# Altium schematic sheet 04_ZYNQ_Config.SchDoc(04_ZYNQ_Config)
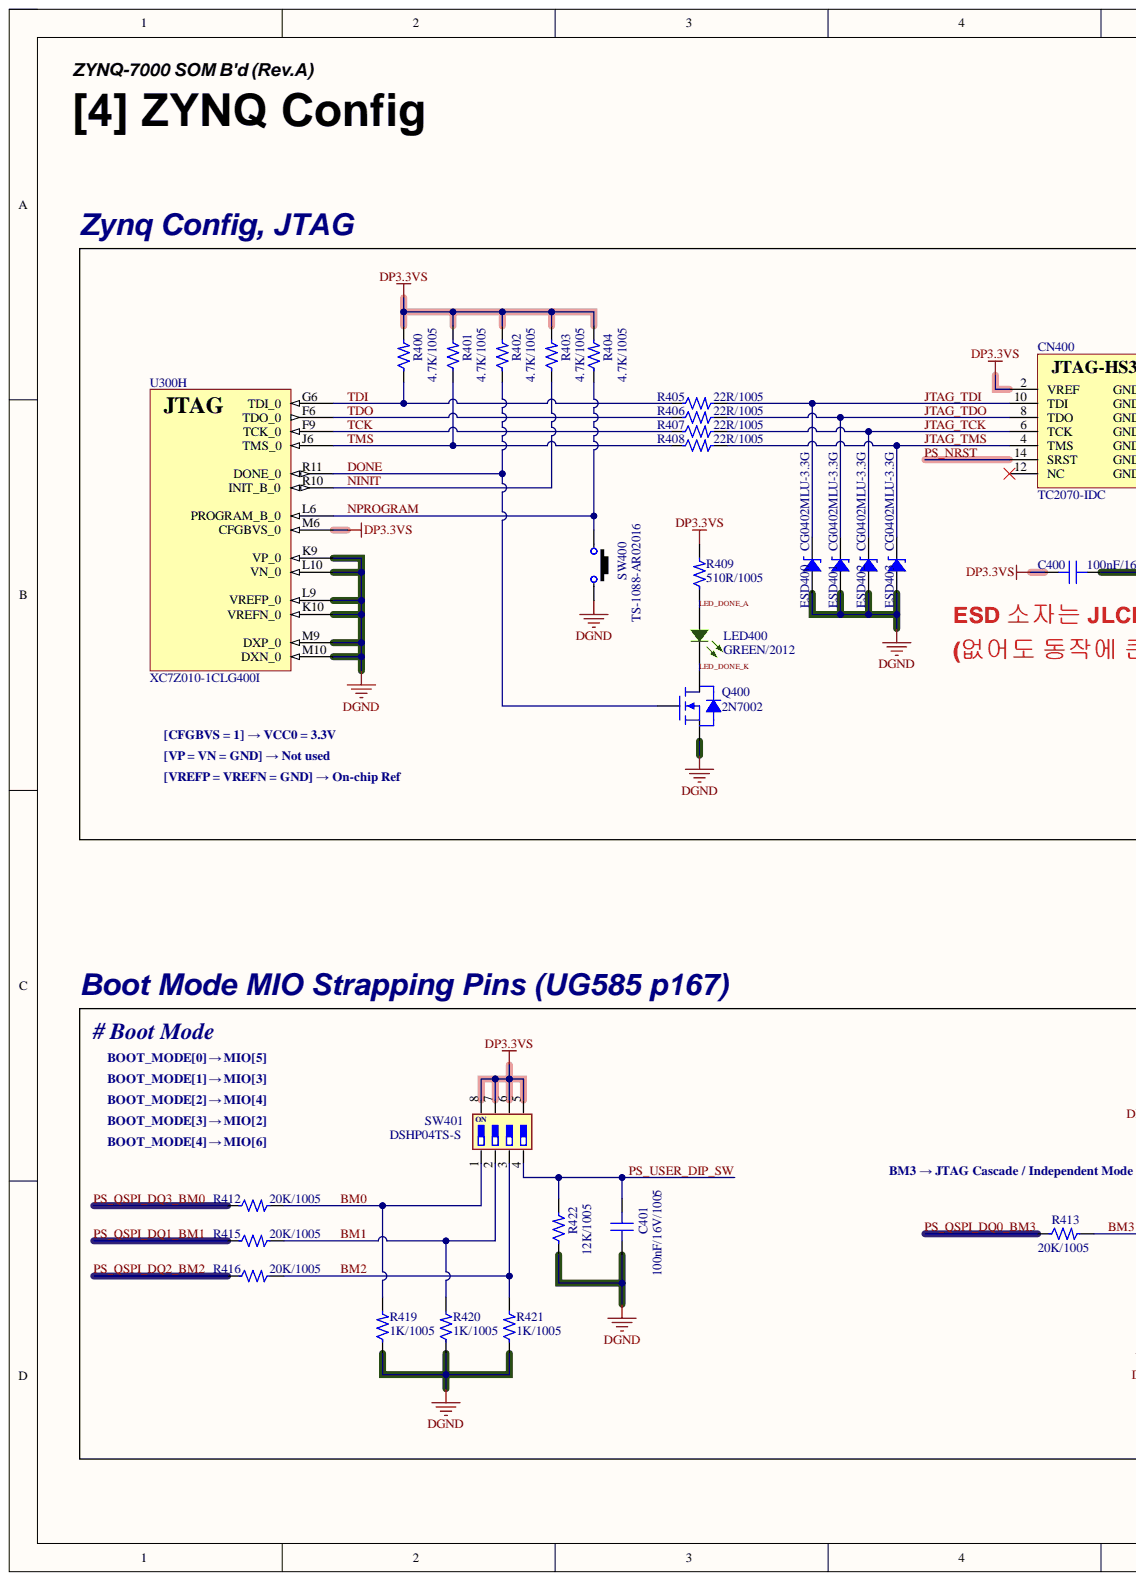
[diagram p0: 04_ZYNQ_Config.SchDoc, left]
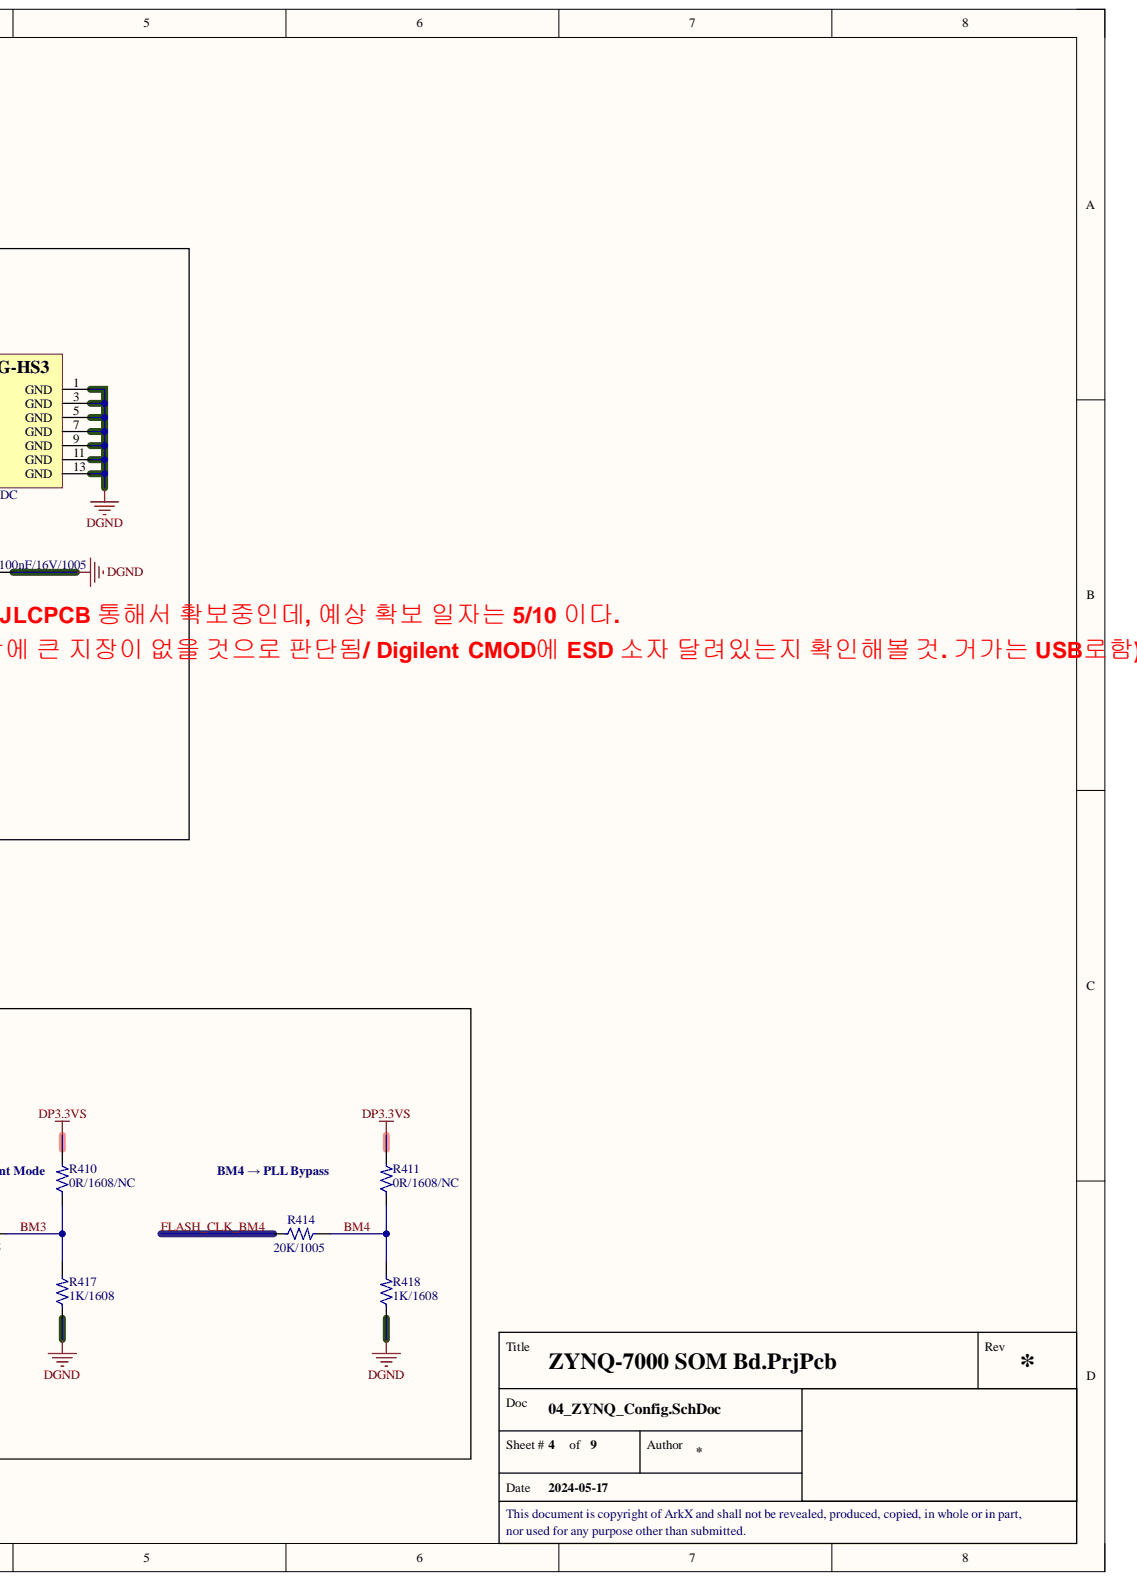
[diagram p1: 04_ZYNQ_Config.SchDoc, right]

## Parts
C400  100nF/16V/1005  [CAP 1005 (0402_INCH)]
C401  100nF/16V/1005  [CAP 1005 (0402_INCH)]
CN400  TC2070-IDC  [CON_TC2070-IDC]
ESD400  CG0402MLU-3.3G  [RES 1005 (0402_inch)]
ESD401  CG0402MLU-3.3G  [RES 1005 (0402_inch)]
ESD402  CG0402MLU-3.3G  [RES 1005 (0402_inch)]
ESD403  CG0402MLU-3.3G  [RES 1005 (0402_inch)]
LED400  GREEN/2012  [LED MHT170RGBCT (GREEN)]
Q400  2N7002  [SOT 23-3 (DIODES INCORPORATED)]
R400  4.7K/1005  [RES 1005 (0402_inch)]
R401  4.7K/1005  [RES 1005 (0402_inch)]
R402  4.7K/1005  [RES 1005 (0402_inch)]
R403  4.7K/1005  [RES 1005 (0402_inch)]
R404  4.7K/1005  [RES 1005 (0402_inch)]
R405  22R/1005  [RES 1005 (0402_inch)]
R406  22R/1005  [RES 1005 (0402_inch)]
R407  22R/1005  [RES 1005 (0402_inch)]
R408  22R/1005  [RES 1005 (0402_inch)]
R409  510R/1005  [RES 1005 (0402_inch)]
R410  0R/1608/NC  [RES 1608 (0603_inch)/NC]
R411  0R/1608/NC  [RES 1608 (0603_inch)/NC]
R412  20K/1005  [RES 1005 (0402_inch)]
R413  20K/1005  [RES 1005 (0402_inch)]
R414  20K/1005  [RES 1005 (0402_inch)]
R415  20K/1005  [RES 1005 (0402_inch)]
R416  20K/1005  [RES 1005 (0402_inch)]
R417  1K/1608  [RES 1608 (0603_inch)]
R418  1K/1608  [RES 1608 (0603_inch)]
R419  1K/1005  [RES 1005 (0402_inch)]
R420  1K/1005  [RES 1005 (0402_inch)]
R421  1K/1005  [RES 1005 (0402_inch)]
R422  12K/1005  [RES 1005 (0402_inch)]
SW400  TS-1088-AR02016  [SW_TS-1088-AR02016]
SW401  DSHP04TS-S  [SW_DSHP04TS-S]
U300  XC7Z010-1CLG400I  [IC_SOC_XC7Z010-1CLG400I]  (part H)

## Nets
DGND: C400.1 C401.1 CN400.1(GND) CN400.3(GND) CN400.5(GND) CN400.7(GND) CN400.9(GND) CN400.11(GND) CN400.13(GND) ESD400.1 ESD401.1 ESD402.1 ESD403.1 Q400.2 R417.1 R418.1 R419.1 R420.1 R421.1 R422.1 SW400.2 U300.K9(VP_0) U300.K10(VREFN_0) U300.L9(VREFP_0) U300.L10(VN_0) U300.M9(DXP_0) U300.M10(DXN_0)
DP3.3VS: C400.2 CN400.2(VREF) R400.2 R401.2 R402.2 R403.2 R404.2 R409.2 R410.2 R411.2 SW401.5 SW401.6 SW401.7 SW401.8(ON) U300.M6(CFGBVS_0)
BM0: R412.2 R419.2 SW401.1
BM1: R415.2 R420.2 SW401.2
BM2: R416.2 R421.2 SW401.3
BM3: R410.1 R413.2 R417.2
BM4: R411.1 R414.2 R418.2
DONE: Q400.1 R402.1 U300.R11(DONE_0)
FLASH_CLK_BM4: R414.1
JTAG_TCK: CN400.6(TCK) ESD402.2 R407.2
JTAG_TDI: CN400.10(TDI) ESD400.2 R405.2
JTAG_TDO: CN400.8(TDO) ESD401.2 R406.2
JTAG_TMS: CN400.4(TMS) ESD403.2 R408.2
LED_DONE_A: LED400.4 R409.1
LED_DONE_K: LED400.2 Q400.3
NINIT: R403.1 U300.R10(INIT_B_0)
NPROGRAM: R404.1 SW400.1 U300.L6(PROGRAM_B_0)
PS_NRST: CN400.14(SRST)
PS_QSPI_DQ0_BM3: R413.1
PS_QSPI_DQ1_BM1: R415.1
PS_QSPI_DQ2_BM2: R416.1
PS_QSPI_DQ3_BM0: R412.1
PS_USER_DIP_SW: C401.2 R422.2 SW401.4
TCK: R407.1 U300.F9(TCK_0)
TDI: R400.1 R405.1 U300.G6(TDI_0)
TDO: R406.1 U300.F6(TDO_0)
TMS: R401.1 R408.1 U300.J6(TMS_0)

Not connected: CN400 12(NC)

## Library data of the parts
C400 C401 = CAP_100nF/16V/1005: Ceramic CAP_100nF/16V/1005; Component Kind: Standard; JLCPCB Part Type: Basic Part; LCSC Part #: C1525; Library Name: Arkx.IntLib
CN400 = CON_TC2070-IDC(JTAG-HS3): TC2070 14-pin Plug-of-Nails™ (with legs) to 14 pin 2mm female IDC connector.; Component Kind: Standard; Library Name: Arkx.IntLib
ESD400-ESD403 = ESD_CG0402MLU-3.3G: Varistor 1 Circuit Surface Mount, MLCV 0402 (1005 Metric); Component Kind: Standard; JLCPCB Part Type: Extended Part; LCSC Part #: C2655393; Library Name: Arkx.IntLib
LED400 = LED_MHT170RGBCT(GREEN): Colorless transparence -30℃~+85℃ Positive post Common anode RGB 120° 0805  Light Emitting Diodes (LED) ROHS; Component Kind: Standard; JLCPCB Part Type: Extended Part; LCSC Part #: C2962094; Library Name: Arkx.IntLib
Q400 = FET_2N7002: 60V 115mA 200mW 7.5Ω@10V,500mA N Channel SOT-23  MOSFETs ROHS; Component Kind: Standard; JLCPCB Part Type: Basic Part; LCSC Part #: C8545; Library Name: Arkx.IntLib
R400-R404 = RES_4.7K/1005/62.5mW: Power Rating: 62.5mW; Precision: 1%; Value: 4.7K; Component Kind: Standard; Description: RES_4.7K/1005/62.5mW; JLCPCB Part Type: Basic Part; LCSC Part #: C25900; Size: 1005; Library Name: Arkx.IntLib
R405-R408 = RES_22R/1005/62.5mW: Power Rating: 62.5mW; Precision: 1%; Value: 22R; Component Kind: Standard; Description: RES_22R/1005/62.5mW; JLCPCB Part Type: Basic Part; LCSC Part #: C25092; Size: 1005; Library Name: Arkx.IntLib
R409 = RES_510R/1005/62.5mW: Power Rating: 62.5mW; Precision: 1%; Value: 510R; Component Kind: Standard; Description: RES_510R/1005/62.5mW; JLCPCB Part Type: Basic Part; LCSC Part #: C25123; Size: 1005; Library Name: Arkx.IntLib
R410 R411 = RES_NC_0R/1608/0.1W: 0R/1608/0.1W/NC; Power Rating: 0.1W; Precision: 1%; Value: 0R; Component Kind: Standard; Size: 1608; Library Name: Arkx.IntLib
R412-R416 = RES_20K/1005/62.5mW: Power Rating: 62.5mW; Precision: 1%; Value: 20K; Component Kind: Standard; Description: RES_20K/1005/62.5mW; JLCPCB Part Type: Basic Part; LCSC Part #: C25765; Size: 1005; Library Name: Arkx.IntLib
R417 R418 = RES_1K/1608/0.1W: Power Rating: 0.1W; Precision: 1%; Value: 1K; Component Kind: Standard; Description: RES_1K/1608/0.1W; JLCPCB Part Type: Basic Part; LCSC Part #: C21190; Size: 1608; Library Name: Arkx.IntLib
R419-R421 = RES_1K/1005/62.5mW: Power Rating: 62.5mW; Precision: 1%; Value: 1K; Component Kind: Standard; Description: RES_1K/1005/62.5mW; JLCPCB Part Type: Basic Part; LCSC Part #: C11702; Size: 1005; Library Name: Arkx.IntLib
R422 = RES_12K/1005/62.5mW: Power Rating: 62.5mW; Precision: 1%; Value: 12K; Component Kind: Standard; Description: RES_12K/1005/62.5mW; JLCPCB Part Type: Basic Part; LCSC Part #: C25752; Size: 1005; Library Name: Arkx.IntLib
SW400 = SW_TS-1088-AR02016: NO 50mA 100MΩ 100000 Times 12V 160gf@±50gf Brick nogging SPST SMD  Tactile Switches ROHS; Component Kind: Standard; JLCPCB Part Type: Extended Part; LCSC Part #: C720477; Library Name: Arkx.IntLib
SW401 = SW_DSHP04TS-S: 4Bit SPST 24V 25mA Black 3千次 Slide (Standard),凹槽式 SMD-8P,5.4x6.7mm  DIP Switches ROHS; Component Kind: Standard; JLCPCB Part Type: Extended Part; LCSC Part #: C319050; Library Name: Arkx.IntLib
U300 = IC_SOC_XC7Z010-1CLG400I: Dual ARM® Cortex®-A9 MPCore™ with CoreSight™ System On Chip (SOC) IC Zynq®-7000 Artix™-7 FPGA, 28K Logic Cells 766MHz 400-CSPBGA (17x17); Component Kind: Standard; JLCPCB Part Type: Extended Part; LCSC Part #: C2840994; Library Name: Arkx.IntLib
